# Altium schematic sheet CAN.SchDoc
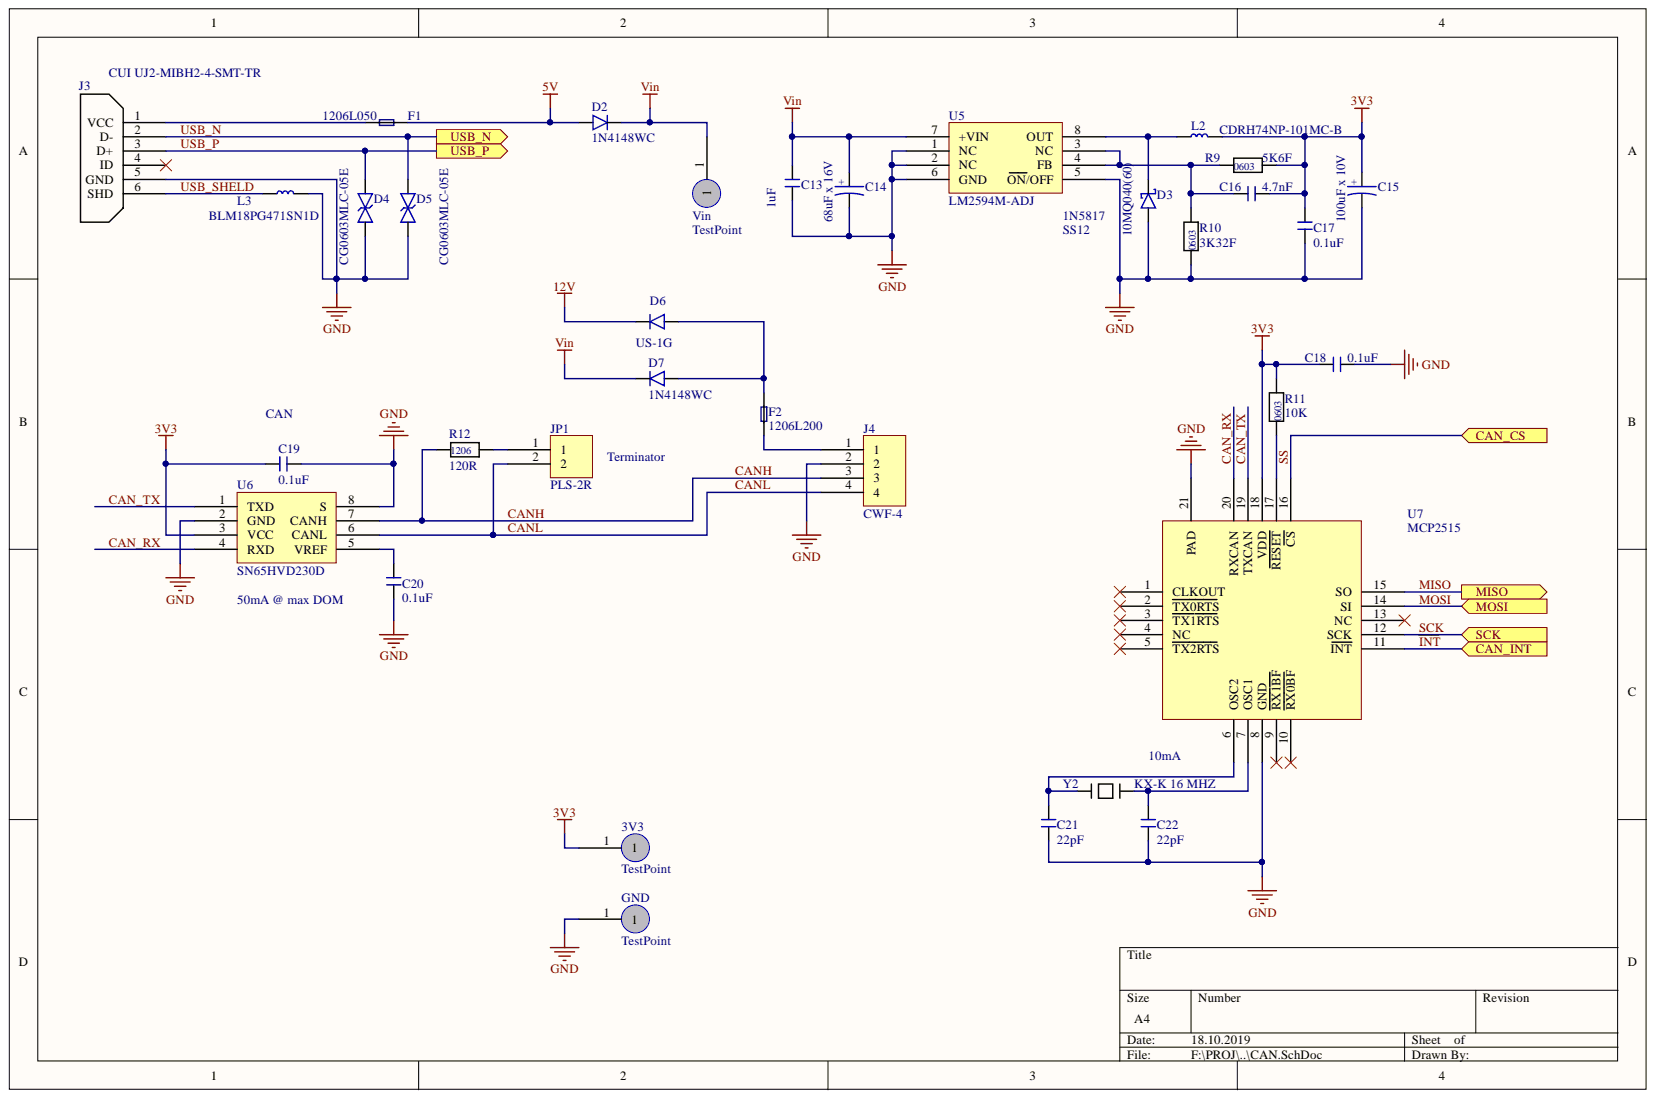
[diagram p0: CAN.SchDoc]

## Parts
3V3  TestPoint  [TestPoint]
C13  1uF  [CHIP_C_0805]
C14  68uF x 16V  [TANTAL - C]
C15  100uF x 10V  [Tantal - B]
C16  4.7nF  [CHIP_C_0603]
C17  0.1uF  [CHIP_C_0603]
C18  0.1uF  [CHIP_C_0603]
C19  0.1uF  [CHIP_C_0603]
C20  0.1uF  [CHIP_C_0603]
C21  22pF  [CHIP_C_0603]
C22  22pF  [CHIP_C_0603]
D2  1N4148WC  [SOD323]
D3  10MQ040(60)  [DO214-AC]
D4  CG0603MLC-05E  [CG0603MLC-05E]
D5  CG0603MLC-05E  [CG0603MLC-05E]
D6  US-1G  [DO214-AC]
D7  1N4148WC  [SOD323]
F1  1206L050  [1206Lxxx]
F2  1206L200  [1206Lxxx]
GND  TestPoint  [TestPoint]
J3  CUI UJ2-MIBH2-4-SMT-TR  [UJ2-MIBH2-4-SMT]
J4  CWF-4  [CWF-4R]
JP1  PLS-2R  [PLS-2R]
L2  CDRH74NP-101MC-B  [CDRH74]
L3  BLM18PG471SN1D  [CHIP_R_0603]
R9  5K6F  [CHIP_R_0603]
R10  3K32F  [CHIP_R_0603]
R11  10K  [CHIP_R_0603]
R12  120R  [CHIP_R_1206]
U5  LM2594M-ADJ  [SOIC8]
U6  SN65HVD230D  [SOIC8]
U7  MCP2515  [QFN20]
Vin  TestPoint  [TestPoint]
Y2  KX-K 16 MHZ  [CHIP_CRYSTAL_5032]

## Ports
CAN_CS, CAN_INT, MISO, MOSI, SCK, USB_N, USB_P

## Nets
3V3: 3V3.1 C15.1 C16.1 C17.2 C18.2 C19.2 L2.2 R9.2 R11.2 U6.3(VCC) U7.18(VDD)
5V: D2.2 F1.2
12V: D6.2
GND: C13.1 C14.2 C15.2 C17.1 C18.1 C19.1 C20.1 C21.1 C22.1 D3.1 D4.2 D5.2 GND.1 J3.5(GND) J4.2 L3.2 R10.1 U5.1(NC) U5.2(NC) U5.5(ON/OFF) U5.6(GND) U6.2(GND) U6.8(S) U7.8(GND) U7.21(PAD)
VIN: C13.2 C14.1 D2.1 D7.1 U5.7(+VIN) Vin.1
CAN_RX: U6.4(RXD) U7.20(RXCAN)
CAN_TX: U6.1(TXD) U7.19(TXCAN)
CANH: J4.3 R12.1 U6.7(CANH)
CANL: J4.4 JP1.2 U6.6(CANL)
~{INT}: U7.11(INT) ; port CAN_INT
MISO: U7.15(SO) ; port MISO
MOSI: U7.14(SI) ; port MOSI
SCK: U7.12(SCK) ; port SCK
SS: U7.16(CS) ; port CAN_CS
USB_N: D5.1 J3.2(D-) ; port USB_N
USB_P: D4.1 J3.3(D+) ; port USB_P
USB_SHELD: J3.6(SHD) L3.1
NetC16_2: C16.2 R9.1 R10.2 U5.3(NC) U5.4(FB)
NetC20_2: C20.2 U6.5(VREF)
NetC21_2: C21.2 U7.6(OSC2) Y2.1
NetC22_2: C22.2 U7.7(OSC1) Y2.2
NetD3_2: D3.2 L2.1 U5.8(OUT)
NetD6_1: D6.1 D7.2 F2.2
NetF1_1: F1.1 J3.1(VCC)
NetF2_1: F2.1 J4.1
NetJP1_1: JP1.1 R12.2
NetR11_1: R11.1 U7.17(RESET)

Not connected: J3 4(ID); U7 1(CLKOUT) 2(TX0RTS) 3(TX1RTS) 4(NC) 5(TX2RTS) 9(RX1BF) 10(RX0BF) 13(NC)

## Library data of the parts
C13 C16-C22 = CHIP_C: Component Kind: Standard; Library Name: Popular.SchLib
C14 C15 = Tantal: Component Kind: Standard; Library Name: Popular.SchLib
D3 = 10MQ040: Rectifier diode; Component Kind: Standard; Library Name: Popular.SchLib
D4 D5 = SM6T27CA: Component Kind: Standard; Library Name: Popular.SchLib
F1 F2 = miniSMDC014F-2: Component Kind: Standard; Library Name: Popular.SchLib
J3 = USB_micro: Micro USB connector; Component Kind: Standard; Library Name: Popular.SchLib
JP1 = PLS-2: Component Kind: Standard; Library Name: Popular.SchLib
L2 = CDRH74: Component Kind: Standard; Library Name: Popular.SchLib
L3 = BLM: Component Kind: Standard; Library Name: Popular.SchLib
R9-R11 = RES_0603: Component Kind: Standard; Library Name: Popular.SchLib
R12 = RES_1206: Component Kind: Standard; Library Name: Popular.SchLib
U5 = LM2594: Component Kind: Standard; Library Name: Popular.SchLib
U6 = TJA1050: Component Kind: Standard; Library Name: Popular.SchLib
U7 = MCP2515_QFN: Component Kind: Standard; Library Name: Popular.SchLib
Y2 = Quartz: Component Kind: Standard; Library Name: Popular.SchLib
3V3 GND Vin = TestPoint: Component Kind: Standard; Library Name: Popular.SchLib
D2 D7 = 1N4148WC: Component Kind: Standard; Library Name: Popular.SchLib
D6 = US-1G: Component Kind: Standard; Library Name: Popular.SchLib
J4 = CWF-4: Component Kind: Standard; Library Name: Popular.SchLib
